# Altium schematic sheet SD.SchDoc
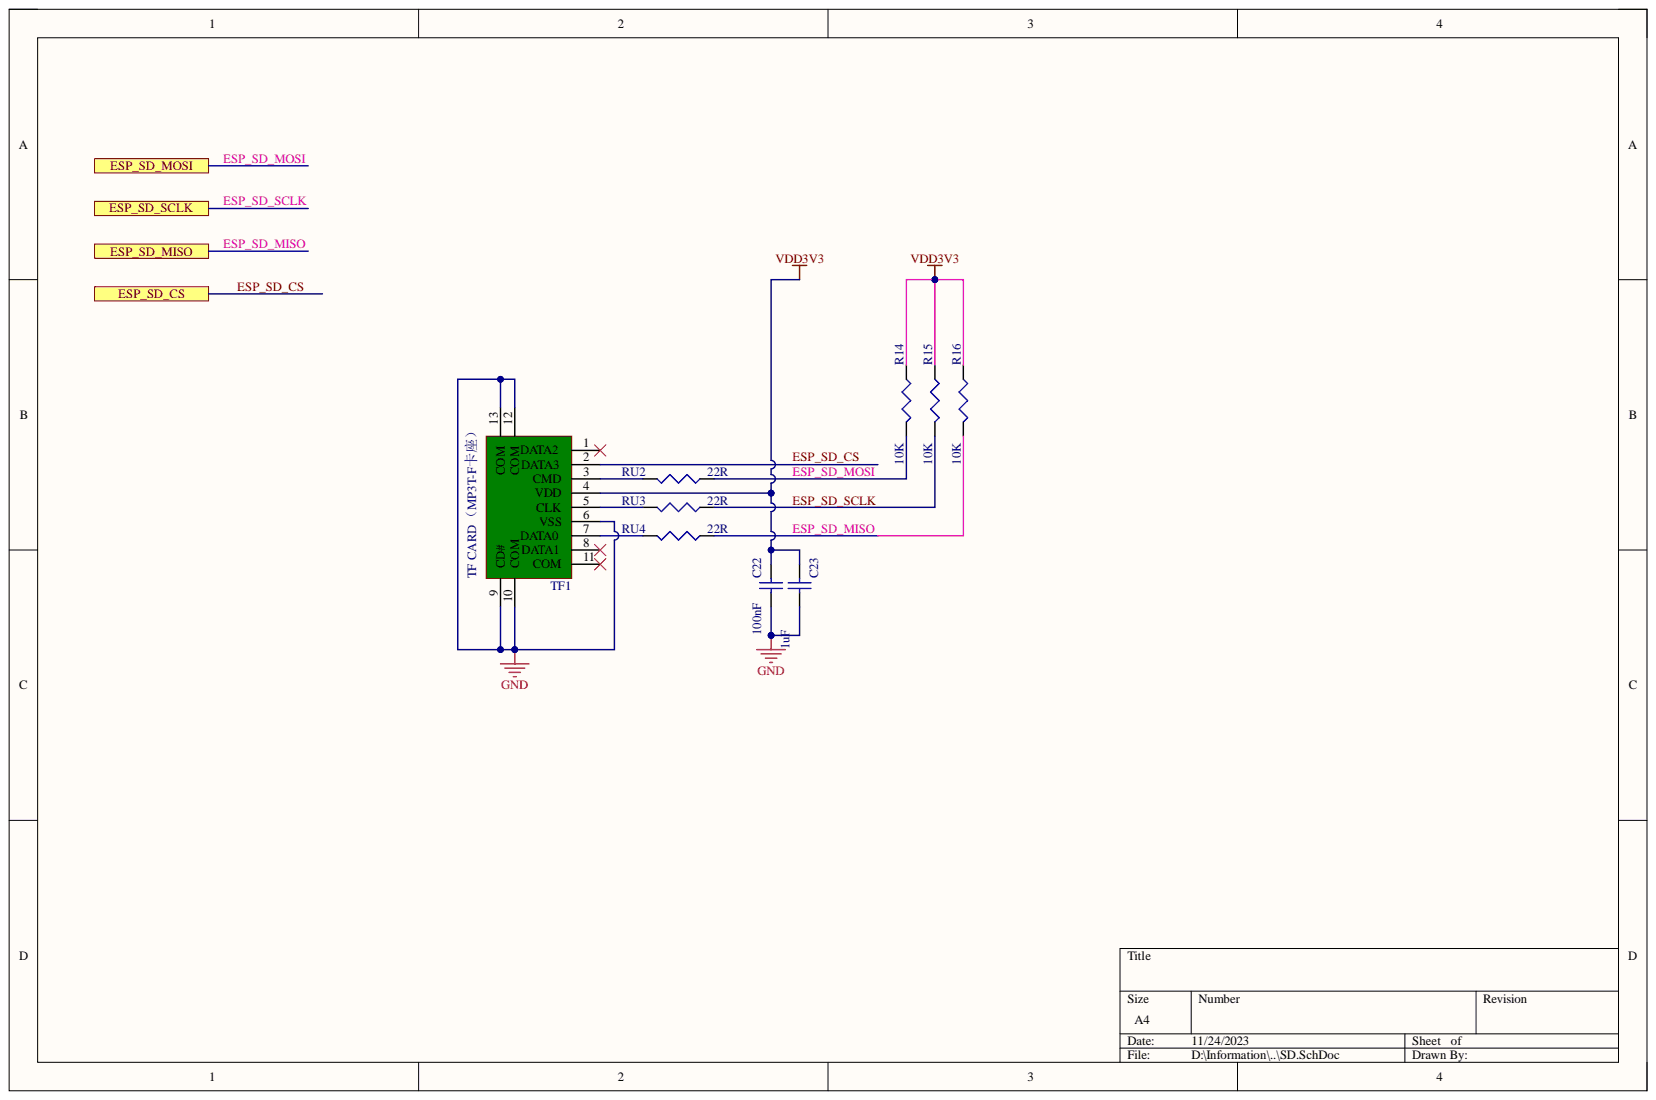
[diagram p0: SD.SchDoc]

## Parts
C22  100nF  [LC-0402_C]
C23  1uF  [LC-0402_C]
R14  10K  [LC-0402_R]
R15  10K  [LC-0402_R]
R16  10K  [LC-0402_R]
RU2  22R  [LC-0402_R]
RU3  22R  [LC-0402_R]
RU4  22R  [LC-0402_R]
TF1  TF CARD（MP3T-F卡座）  [TF--CKT01-009D]

## Ports
ESP_SD_CS, ESP_SD_MISO, ESP_SD_MOSI, ESP_SD_SCLK

## Nets
GND: C22.2 C23.2 TF1.6(VSS) TF1.9(CD#) TF1.10(COM) TF1.12(COM) TF1.13(COM)
VDD3V3: C22.1 C23.1 R14.2 R15.2 R16.2 TF1.4(VDD)
ESP_SD_CS: TF1.2(DATA3) ; port ESP_SD_CS
ESP_SD_MISO: R16.1 RU4.2 ; port ESP_SD_MISO
ESP_SD_MOSI: R14.1 RU2.2 ; port ESP_SD_MOSI
ESP_SD_SCLK: R15.1 RU3.2 ; port ESP_SD_SCLK
NetRU2_1: RU2.1 TF1.3(CMD)
NetRU3_1: RU3.1 TF1.5(CLK)
NetRU4_1: RU4.1 TF1.7(DATA0)

Not connected: TF1 1(DATA2) 8(DATA1) 11(COM)

## Library data of the parts
C22 C23 = Cap: Capacitor; Value: 100pF; Component Kind: Standard; LatestRevisionDate: 17-Jul-2002; LatestRevisionNote: Re-released for DXP Platform.; Library Name: Miscellaneous Devices.IntLib; PackageReference: RAD-0.3; Published: 8-Jun-2000; Publisher: Altium Limited
R14-R16 RU2-RU4 = R: Component Kind: Standard
TF1 = TF CARD: Component Kind: Standard; Library Name: K210--Crazy.SCHLIB
